# Altium schematic sheet P01_Connector.SchDoc
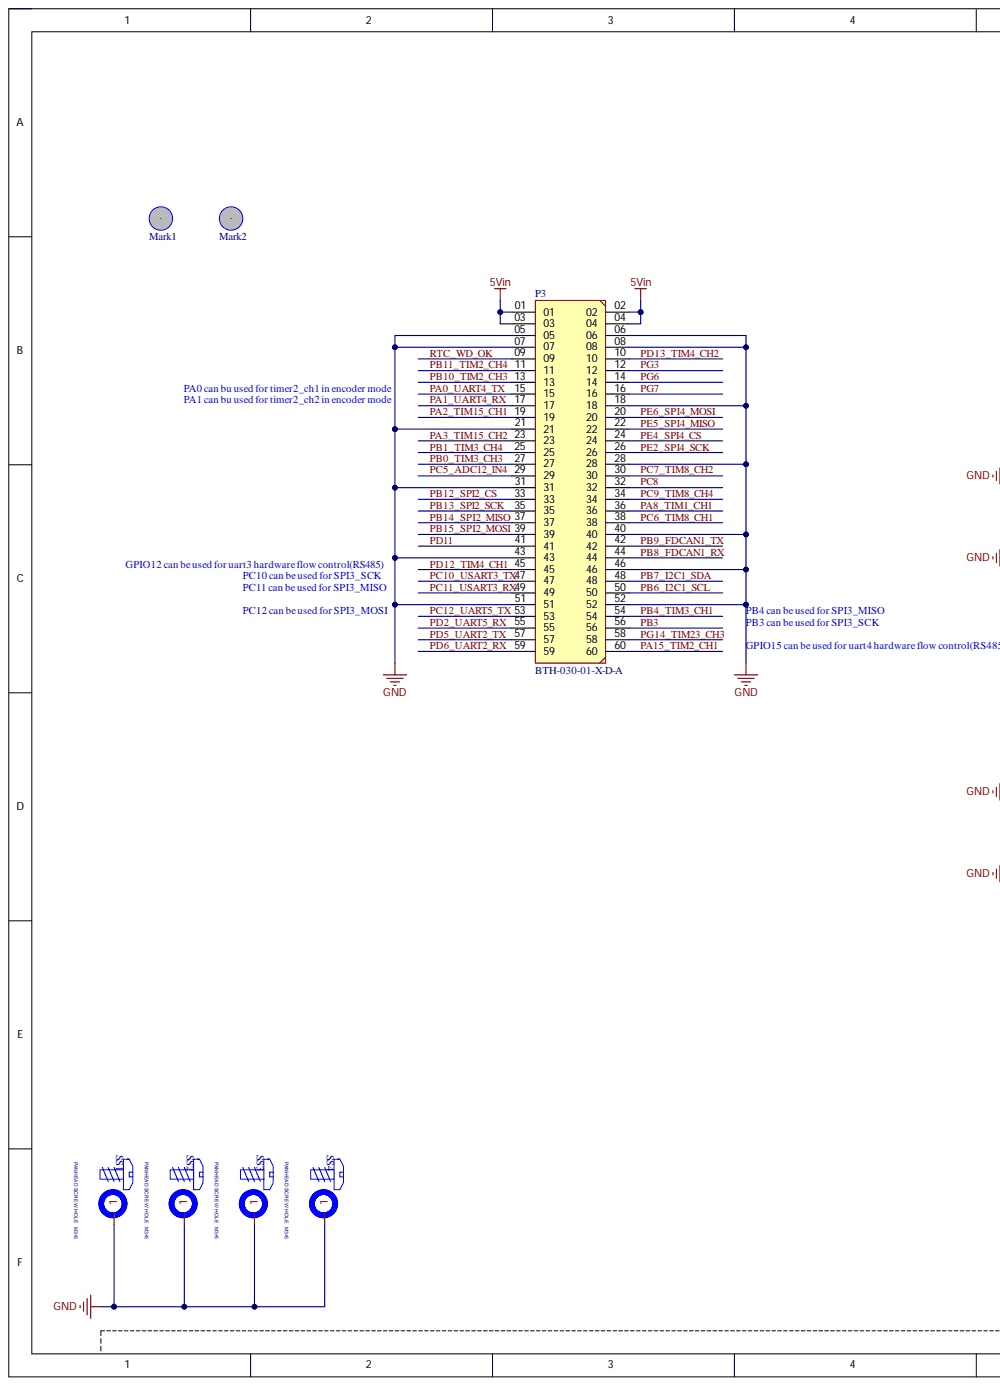
[diagram p0: P01_Connector.SchDoc, left]
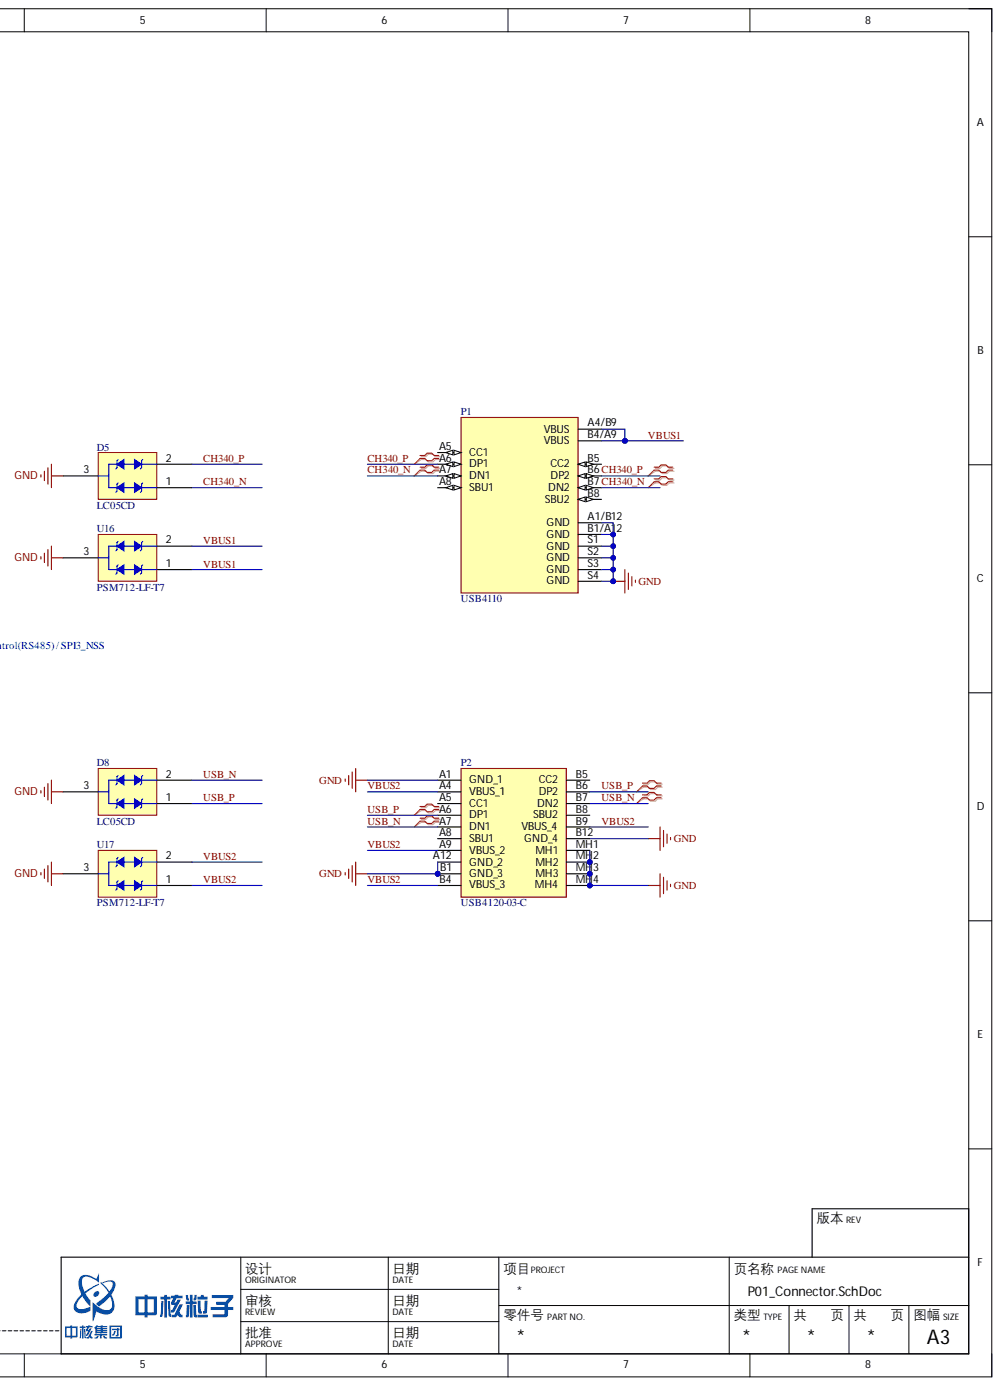
[diagram p1: P01_Connector.SchDoc, right]

## Parts
D5  LC05CD  [LC05CD_SOT-23(SOT-23-3)]
D8  LC05CD  [LC05CD_SOT-23(SOT-23-3)]
Mark1  Mark  [Mark]
Mark2  Mark  [Mark]
P1  USB4110  [GCT_USB4110-GF-A_REVB]
P2  USB4120-03-C  [USB412003C]
P3  BTH-030-01-X-D-A  [BTH-030-01-L-D-A]
SS1  PANHEAD SCREW HOLE  M3×5  [MOUNTING_HOLE_3MM_RND - GND]
SS2  PANHEAD SCREW HOLE  M3×5  [MOUNTING_HOLE_3MM_RND - GND]
SS3  PANHEAD SCREW HOLE  M3×5  [MOUNTING_HOLE_3MM_RND - GND]
SS4  PANHEAD SCREW HOLE  M3×5  [MOUNTING_HOLE_3MM_RND - GND]
U16  PSM712-LF-T7  [SOT-23(SOT-23-3)]
U17  PSM712-LF-T7  [SOT-23(SOT-23-3)]

## Nets
5Vin: P3.01 P3.02 P3.03 P3.04
GND: D5.3 D8.3 P1.A1/B12(GND) P1.B1/A12(GND) P1.S1(GND) P1.S2(GND) P1.S3(GND) P1.S4(GND) P2.A1(GND_1) P2.A12(GND_2) P2.B1(GND_3) P2.B12(GND_4) P2.MH1 P2.MH2 P2.MH3 P2.MH4 P3.05 P3.06 P3.07 P3.08 P3.18 P3.21 P3.28 P3.31 P3.40 P3.43 P3.46 P3.51 P3.52 SS1.1 SS2.1 SS3.1 SS4.1 U16.3 U17.3
CH340_N: D5.1 P1.A7(DN1) P1.B7(DN2)
CH340_P: D5.2 P1.A6(DP1) P1.B6(DP2)
PA0_UART4_TX: P3.15
PA1_UART4_RX: P3.17
PA2_TIM15_CH1: P3.19
PA3_TIM15_CH2: P3.23
PA8_TIM1_CH1: P3.36
PA15_TIM2_CH1: P3.60
PB0_TIM3_CH3: P3.27
PB1_TIM3_CH4: P3.25
PB3: P3.56
PB4_TIM3_CH1: P3.54
PB6_I2C1_SCL: P3.50
PB7_I2C1_SDA: P3.48
PB8_FDCAN1_RX: P3.44
PB9_FDCAN1_TX: P3.42
PB10_TIM2_CH3: P3.13
PB11_TIM2_CH4: P3.11
PB12_SPI2_CS: P3.33
PB13_SPI2_SCK: P3.35
PB14_SPI2_MISO: P3.37
PB15_SPI2_MOSI: P3.39
PC5_ADC12_IN4: P3.29
PC6_TIM8_CH1: P3.38
PC7_TIM8_CH2: P3.30
PC8: P3.32
PC9_TIM8_CH4: P3.34
PC10_USART3_TX: P3.47
PC11_USART3_RX: P3.49
PC12_UART5_TX: P3.53
PD2_UART5_RX: P3.55
PD5_UART2_TX: P3.57
PD6_UART2_RX: P3.59
PD11: P3.41
PD12_TIM4_CH1: P3.45
PD13_TIM4_CH2: P3.10
PE2_SPI4_SCK: P3.26
PE4_SPI4_CS: P3.24
PE5_SPI4_MISO: P3.22
PE6_SPI4_MOSI: P3.20
PG3: P3.12
PG6: P3.14
PG7: P3.16
PG14_TIM23_CH3: P3.58
RTC_WD_OK: P3.09
USB_N: D8.2 P2.A7(DN1) P2.B7(DN2)
USB_P: D8.1 P2.A6(DP1) P2.B6(DP2)
VBUS1: P1.A4/B9(VBUS) P1.B4/A9(VBUS) U16.1 U16.2
VBUS2: P2.A4(VBUS_1) P2.A9(VBUS_2) P2.B4(VBUS_3) P2.B9(VBUS_4) U17.1 U17.2

Not connected: Mark1 1; Mark2 1; P1 A5(CC1) A8(SBU1) B5(CC2) B8(SBU2); P2 A5(CC1) A8(SBU1) B5(CC2) B8(SBU2)

## Library data of the parts
D5 D8 = LC05CD: TVS FOR USB2.0; Component Kind: Standard; Library Name: IC2023.SchLib; Package: SOT-23(SOT-23-3); Supplier: LC; SuppliersPartNumber: C32677
P1 = USB4110-GF-A_REVB: USB-C (USB TYPE-C) USB 2.0 Receptacle Connector 24 (16+8 Dummy) Position Surface Mount, Right Angle; Through Hole; MF: GCT; Availability: In Stock; Check_prices: https://www.snapeda.com/parts/USB4110-GF-A/Global+Connector+Technology/view-part/?ref=eda; Component Kind: Standard; Library Name: Connector2023.SchLib; MP: USB4110-GF-A; Package: None; Price: None; Purchase-URL: https://pricing.snapeda.com/search/part/USB4110-GF-A/?ref=eda; SnapEDA_Link: https://www.snapeda.com/parts/USB4110-GF-A/Global+Connector+Technology/view-part/?ref=snap
P2 = USB4120-03-C: USB Connectors USB C Rec 3u" vert 16P SMT & TH stakes pegs T+R+C H = 9.25; Component Kind: Standard; Library Name: Connector2023.SchLib
P3 = BTH-030-01-X-D-A: 60 Position Connector Socket, Center Strip Contacts Surface Mount Gold; MF: Samtec; MP: BSH-030-01-X-D-A-XX; Availability: Unavailable; Component Kind: Standard; Library Name: Connector2022.SchLib; Package: None; Price: None; System: Connector
SS1-SS4 = Screw Standoff hole_3mm_GND_PE: Grounding the Mounting holes  3mm; Mfr_Name: Building Fasteners; Mfr_Part_Number: PMSSS 440 0038 PH; Note: SCREW FOR STANDOFF; Component Kind: Standard; Library Name: Connector.SchLib
U16 U17 = PSM712-LF-T7: TVS; Component Kind: Standard; ComponentLink1Description: 供应商链接; ComponentLink1URL: http://www.szlcsc.com/product/details_33642.html; Library Name: IC2023.SchLib; Package: SOT-23(SOT-23-3); Supplier: LC; SuppliersPartNumber: C32677
Mark1 Mark2 = Mark: Component Kind: Standard; Description: Mark; Library Name: Connector.SchLib
